# Altium schematic sheet rasp_sheild_i2c.SchDoc
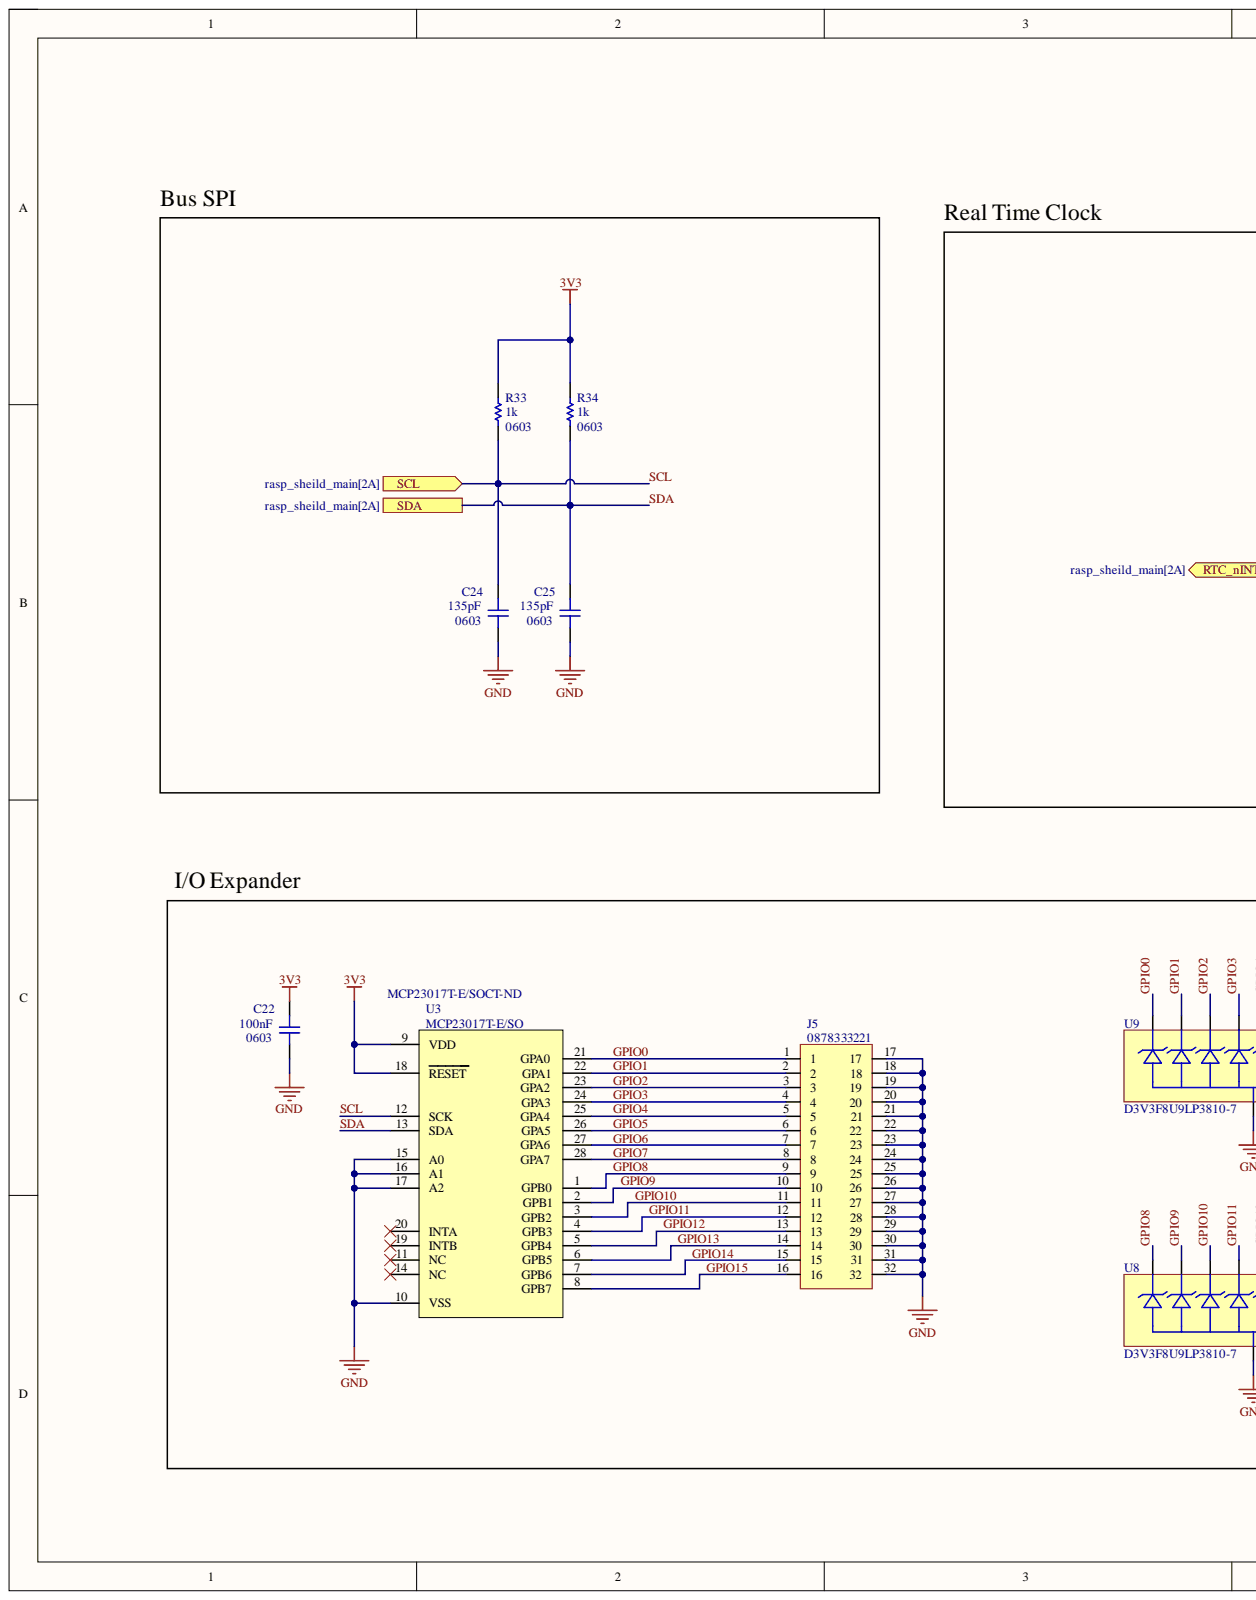
[diagram p0: rasp_sheild_i2c.SchDoc, left]
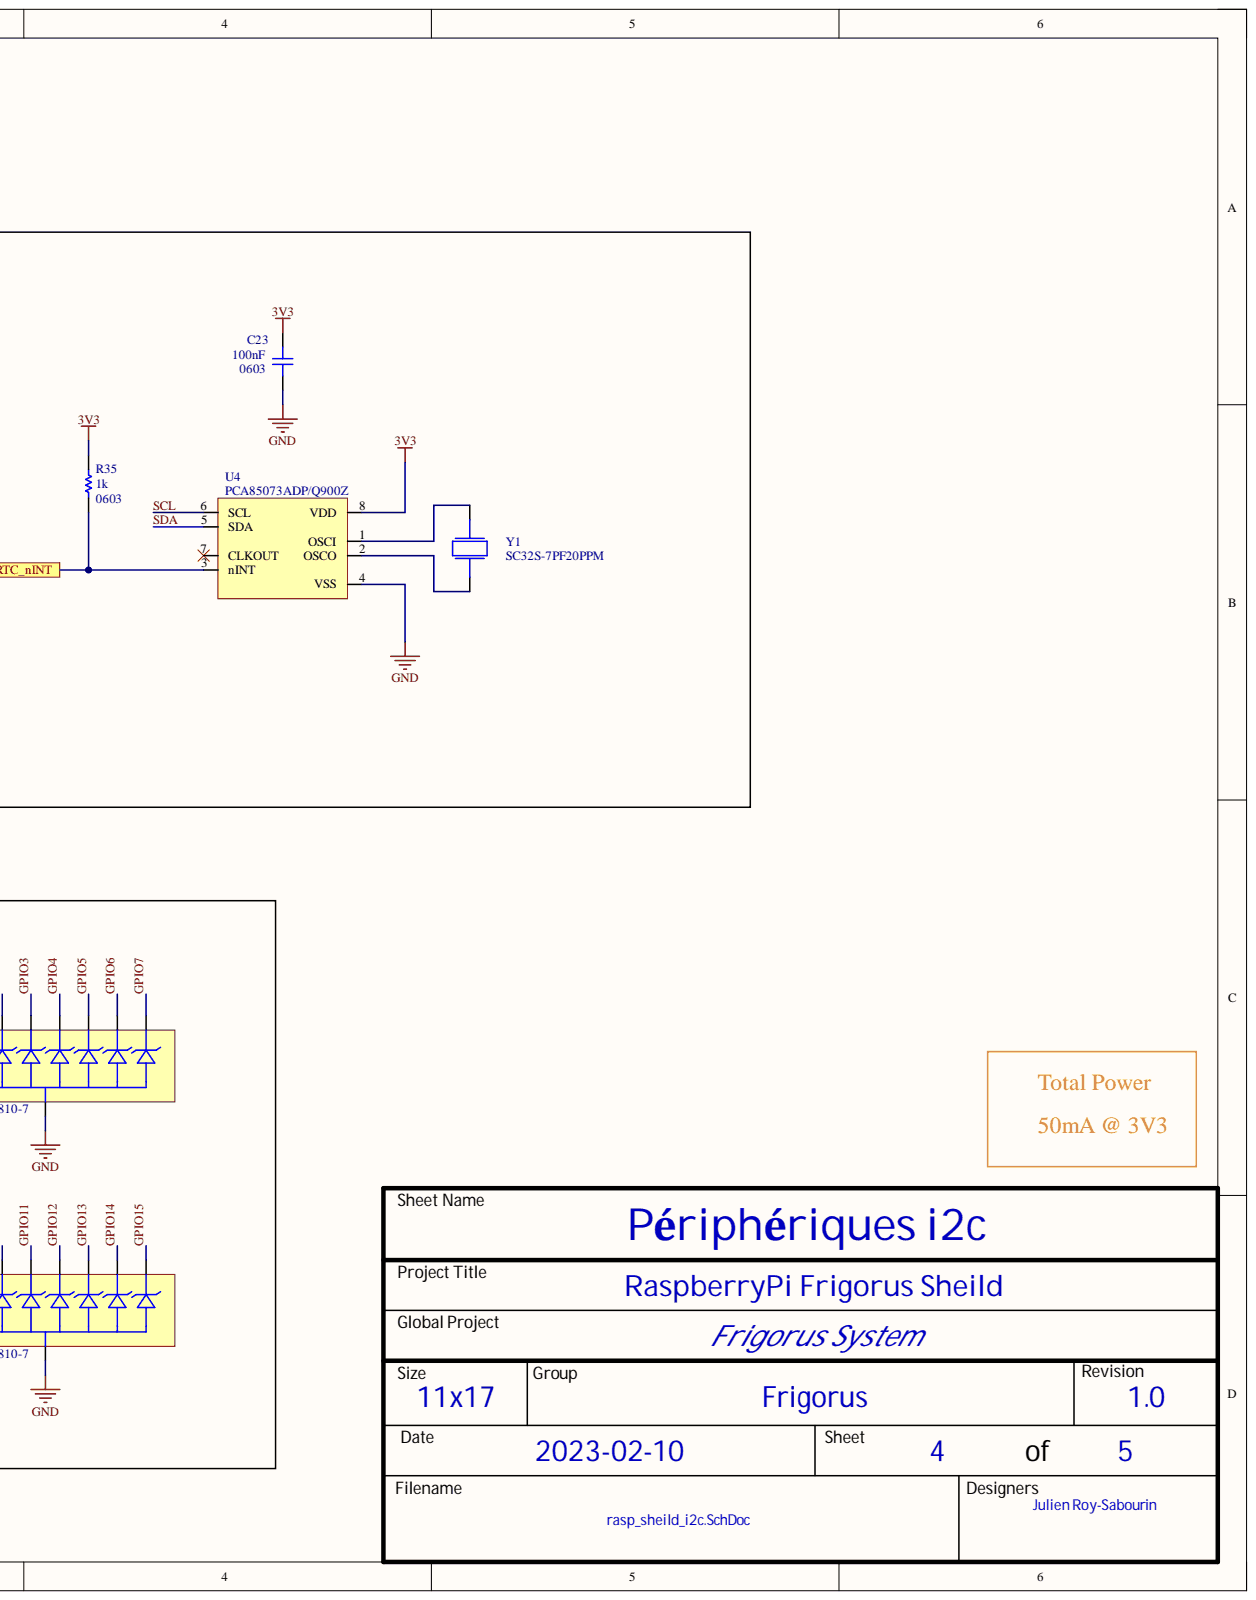
[diagram p1: rasp_sheild_i2c.SchDoc, right]

## Parts
C22  value 100nF  Package: 0603  [CAP_0603]  (hidden comment: Part Number)
C23  value 100nF  Package: 0603  [CAP_0603]  (hidden comment: Part Number)
C24  value 135pF  Package: 0603  [CAP_0603]  (hidden comment: Part Number)
C25  value 135pF  Package: 0603  [CAP_0603]  (hidden comment: Part Number)
J5  0878333221  [0878333221]
R33  value 1k  Package: 0603  [RES_0603]  (hidden comment: Part Number)
R34  value 1k  Package: 0603  [RES_0603]  (hidden comment: Part Number)
R35  value 1k  Package: 0603  [RES_0603]  (hidden comment: Part Number)
U3  MCP23017T-E/SO  Supplier Part Number 1: MCP23017T-E/SOCT-ND  [28-SOIC]
U4  PCA85073ADP/Q900Z  [8-MSOP]
U8  D3V3F8U9LP3810-7  [D3V3F8U9LP3810-7]
U9  D3V3F8U9LP3810-7  [D3V3F8U9LP3810-7]
Y1  SC32S-7PF20PPM  [SC32S-7PF20PPM]

## Ports
RTC_nINT, SCL, SDA

## Nets
3V3: C22.2 C23.2 R33.1 R34.1 R35.1 U3.9(VDD) U3.18(RESET) U4.8(VDD)
GND: C22.1 C23.1 C24.1 C25.1 J5.17 J5.18 J5.19 J5.20 J5.21 J5.22 J5.23 J5.24 J5.25 J5.26 J5.27 J5.28 J5.29 J5.30 J5.31 J5.32 U3.10(VSS) U3.15(A0) U3.16(A1) U3.17(A2) U4.4(VSS) U8.3 U9.3
GPIO0: J5.1 U3.21(GPA0) U9.1
GPIO1: J5.2 U3.22(GPA1) U9.2
GPIO2: J5.3 U3.23(GPA2) U9.4
GPIO3: J5.4 U3.24(GPA3) U9.5
GPIO4: J5.5 U3.25(GPA4) U9.6
GPIO5: J5.6 U3.26(GPA5) U9.7
GPIO6: J5.7 U3.27(GPA6) U9.8
GPIO7: J5.8 U3.28(GPA7) U9.9
GPIO8: J5.9 U3.1(GPB0) U8.1
GPIO9: J5.10 U3.2(GPB1) U8.2
GPIO10: J5.11 U3.3(GPB2) U8.4
GPIO11: J5.12 U3.4(GPB3) U8.5
GPIO12: J5.13 U3.5(GPB4) U8.6
GPIO13: J5.14 U3.6(GPB5) U8.7
GPIO14: J5.15 U3.7(GPB6) U8.8
GPIO15: J5.16 U3.8(GPB7) U8.9
RTC_nINT: R35.2 U4.3(nINT) ; port RTC_nINT
SCL: C24.2 R33.2 U3.12(SCK) U4.6(SCL) ; port SCL
SDA: C25.2 R34.2 U3.13(SDA) U4.5(SDA) ; port SDA
NetU4_1: U4.1(OSCI) Y1.1
NetU4_2: U4.2(OSCO) Y1.2

Not connected: U3 11(NC) 14(NC) 19(INTB) 20(INTA); U4 7(CLKOUT)

## Library data of the parts
C22-C25 = CAP_0603: XXX - Description - Package; GRAMS Lib Spec: 2.7; Component Kind: Standard; Library Name: royj1311.SchLib
J5 = 0878333221: XXX - Description - PackageConnector Header Through Hole, Right Angle 32 position 0.079" (2.00mm); GRAMS Lib Spec: 2.7; Component Kind: Standard; Library Name: royj1311.SchLib
R33-R35 = RES_0603: XXX - Description - Package; GRAMS Lib Spec: 2.7; Component Kind: Standard; Library Name: royj1311.SchLib
U3 = MCP23017T-E/SO: I/O Expander 16 I²C 1.7 MHz 28-SOIC; GRAMS Lib Spec: 2.7; Component Kind: Standard; Supplier 1: Digi-Key; Library Name: royj1311.SchLib
U4 = PCA85073ADP/Q900Z: Real Time Clock (RTC) IC Clock/Calendar I²C 8-TSSOP, 8-MSOP (0.118", 3.00mm Width); GRAMS Lib Spec: 2.7; Component Kind: Standard; Supplier 1: Arrow Electronics; Supplier Part Number 1: PCA85073ADP/Q900Z; Library Name: royj1311.SchLib
U8 U9 = D3V3F8U9LP3810-7: 5V (Typ) Clamp 5A (8/20µs) Ipp Tvs Diode Surface Mount U-DFN3810-9 (Type B); GRAMS Lib Spec: 2.7; Component Kind: Standard; Library Name: royj1311.SchLib
Y1 = SC32S-7PF20PPM: 32.768 kHz ±20ppm Crystal 7pF 70 kOhms 2-SMD, No Lead; GRAMS Lib Spec: 2.7; Component Kind: Standard; Library Name: royj1311.SchLib
